# Altium schematic sheet Output_Block.SchDoc
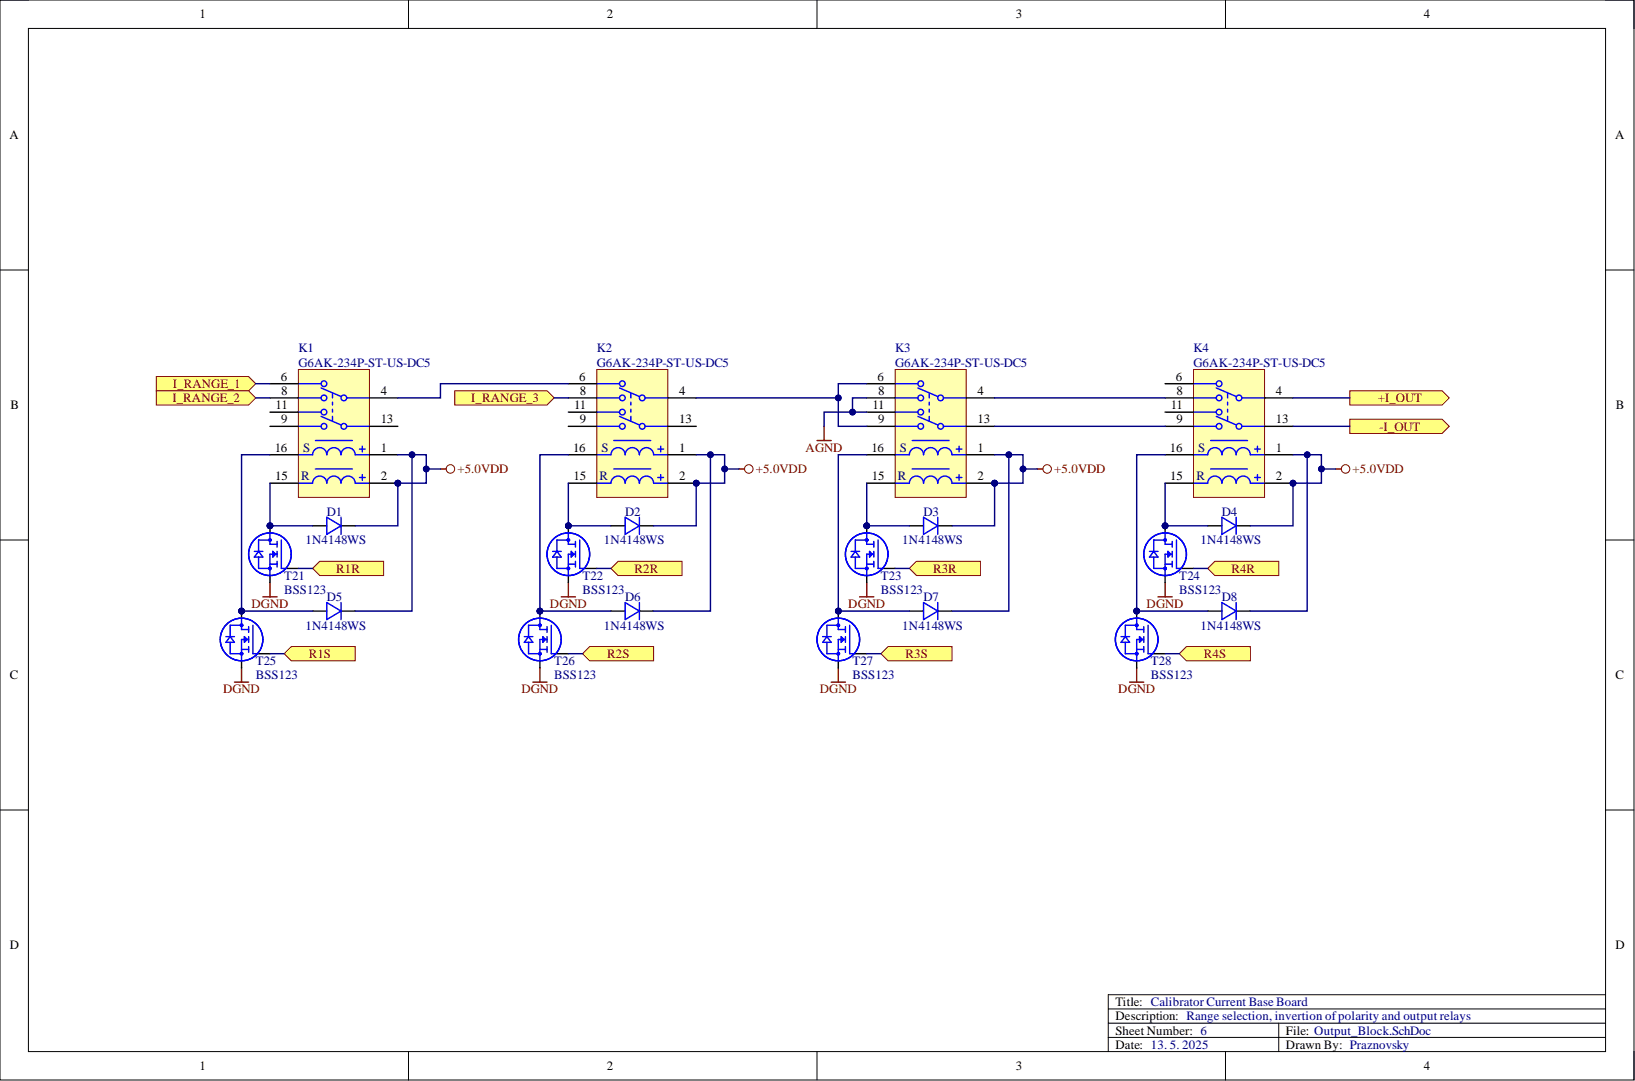
[diagram p0: Output_Block.SchDoc]

## Parts
D1  1N4148WS  [SOD-323F]
D2  1N4148WS  [SOD-323F]
D3  1N4148WS  [SOD-323F]
D4  1N4148WS  [SOD-323F]
D5  1N4148WS  [SOD-323F]
D6  1N4148WS  [SOD-323F]
D7  1N4148WS  [SOD-323F]
D8  1N4148WS  [SOD-323F]
K1  G6AK-234P-ST-US-DC5  [G6AK-xxxP-ST-US]
K2  G6AK-234P-ST-US-DC5  [G6AK-xxxP-ST-US]
K3  G6AK-234P-ST-US-DC5  [G6AK-xxxP-ST-US]
K4  G6AK-234P-ST-US-DC5  [G6AK-xxxP-ST-US]
T21  BSS123  [SOT-23-3]
T22  BSS123  [SOT-23-3]
T23  BSS123  [SOT-23-3]
T24  BSS123  [SOT-23-3]
T25  BSS123  [SOT-23-3]
T26  BSS123  [SOT-23-3]
T27  BSS123  [SOT-23-3]
T28  BSS123  [SOT-23-3]

## Ports
+I_OUT, -I_OUT, I_RANGE_1, I_RANGE_2, I_RANGE_3, R1R, R1S, R2R, R2S, R3R, R3S, R4R, R4S

## Nets
+5.0VDD: D1.1 D2.1 D3.1 D4.1 D5.1 D6.1 D7.1 D8.1 K1.1 K1.2 K2.1 K2.2 K3.1 K3.2 K4.1 K4.2
AGND: K3.8 K3.11
DGND: T21.2 T22.2 T23.2 T24.2 T25.2 T26.2 T27.2 T28.2
+I_OUT: K4.4 ; port +I_OUT
-I_OUT: K4.13 ; port -I_OUT
I_RANGE_1: K1.6 ; port I_RANGE_1
I_RANGE_2: K1.8 ; port I_RANGE_2
I_RANGE_3: K2.8 ; port I_RANGE_3
R1R: T21.1 ; port R1R
R1S: T25.1 ; port R1S
R2R: T22.1 ; port R2R
R2S: T26.1 ; port R2S
R3R: T23.1 ; port R3R
R3S: T27.1 ; port R3S
R4R: T24.1 ; port R4R
R4S: T28.1 ; port R4S
NetD1_2: D1.2 K1.15 T21.3
NetD2_2: D2.2 K2.15 T22.3
NetD3_2: D3.2 K3.15 T23.3
NetD4_2: D4.2 K4.15 T24.3
NetD5_2: D5.2 K1.16(S) T25.3
NetD6_2: D6.2 K2.16(S) T26.3
NetD7_2: D7.2 K3.16(S) T27.3
NetD8_2: D8.2 K4.16(S) T28.3
NetK1_4: K1.4 K2.6
NetK1_9: K1.9
NetK1_11: K1.11
NetK1_13: K1.13
NetK2_4: K2.4 K3.6 K3.9
NetK2_9: K2.9
NetK2_11: K2.11
NetK2_13: K2.13
NetK3_4: K3.4 K4.8
NetK3_13: K3.13 K4.9
NetK4_6: K4.6
NetK4_11: K4.11

## Library data of the parts
D1-D8 = CMP-00058-8: Small Signal Switching Diode 1N4148WS; Component Kind: Standard; Library Name: Calibrator
K1-K4 = CMP-00085-1: Low Signal Relays - PCB DUAL COIL DPDT 5V; Component Kind: Standard; Library Name: Calibrator
T21-T28 = CMP-00033-6: N-channel TrenchMOS transistor Logic level FET; Component Kind: Standard; Library Name: Calibrator
